# Altium schematic sheet 03_BK323334.SchDoc(03_BK323334)
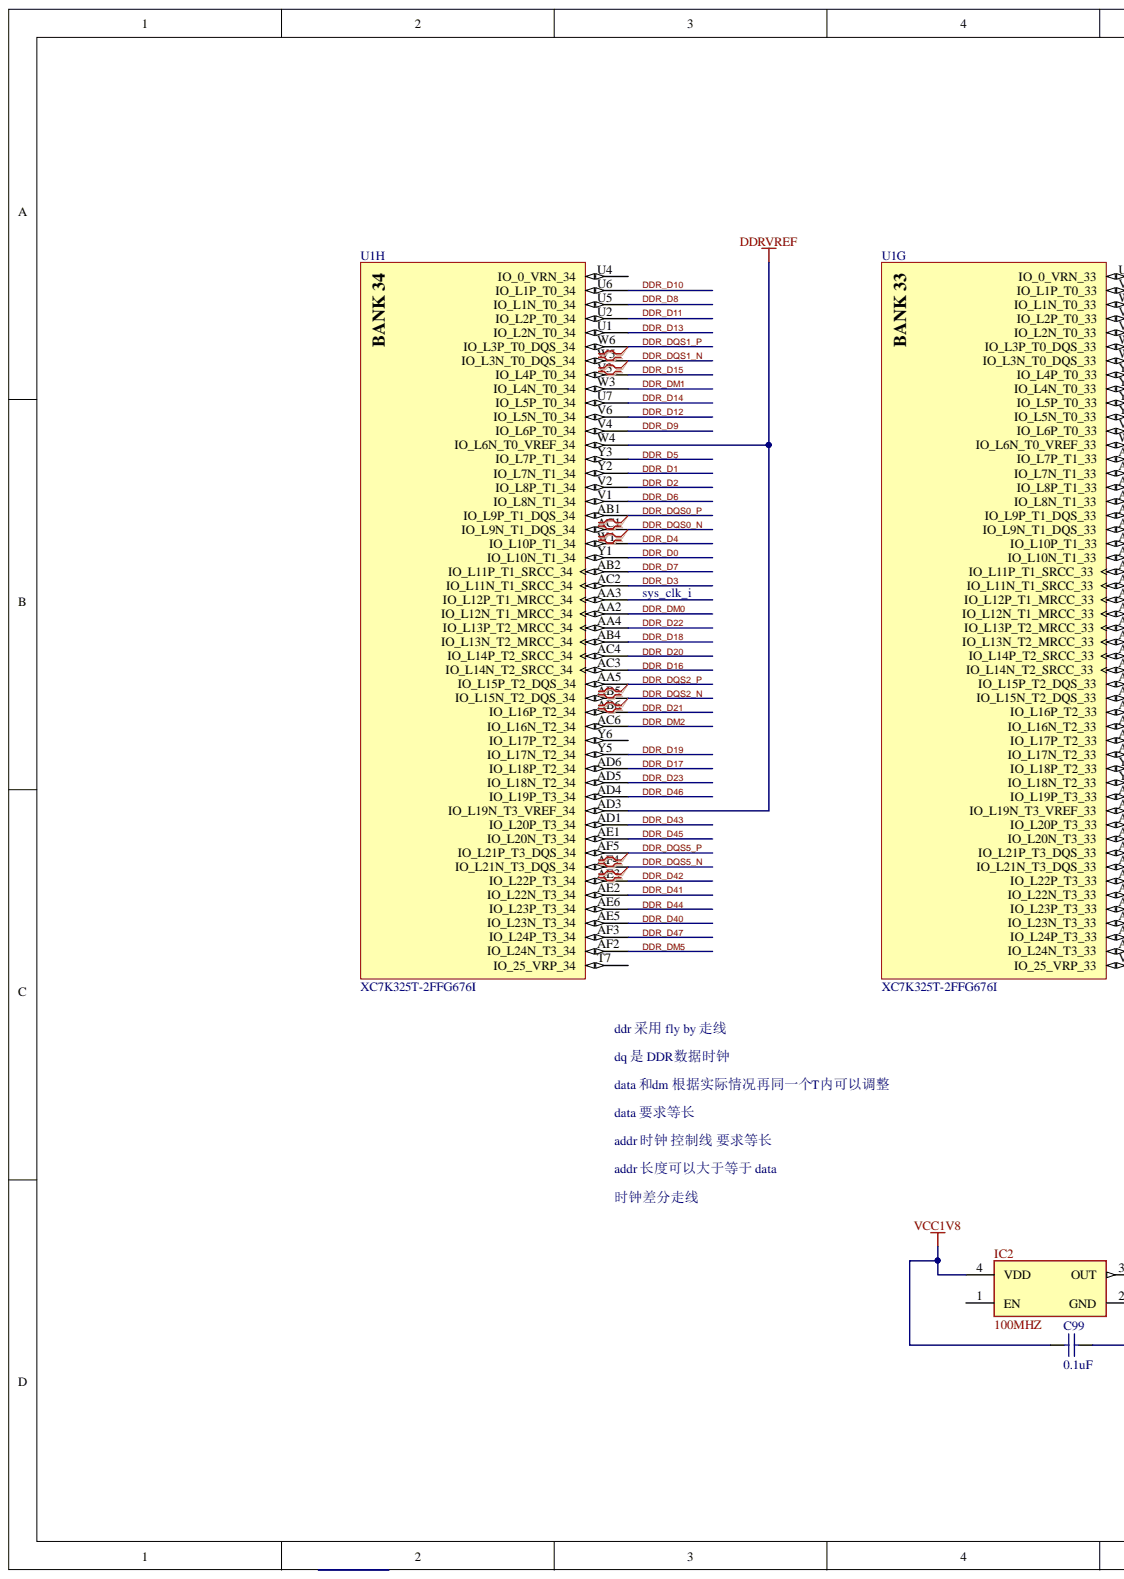
[diagram p0: 03_BK323334.SchDoc, left]
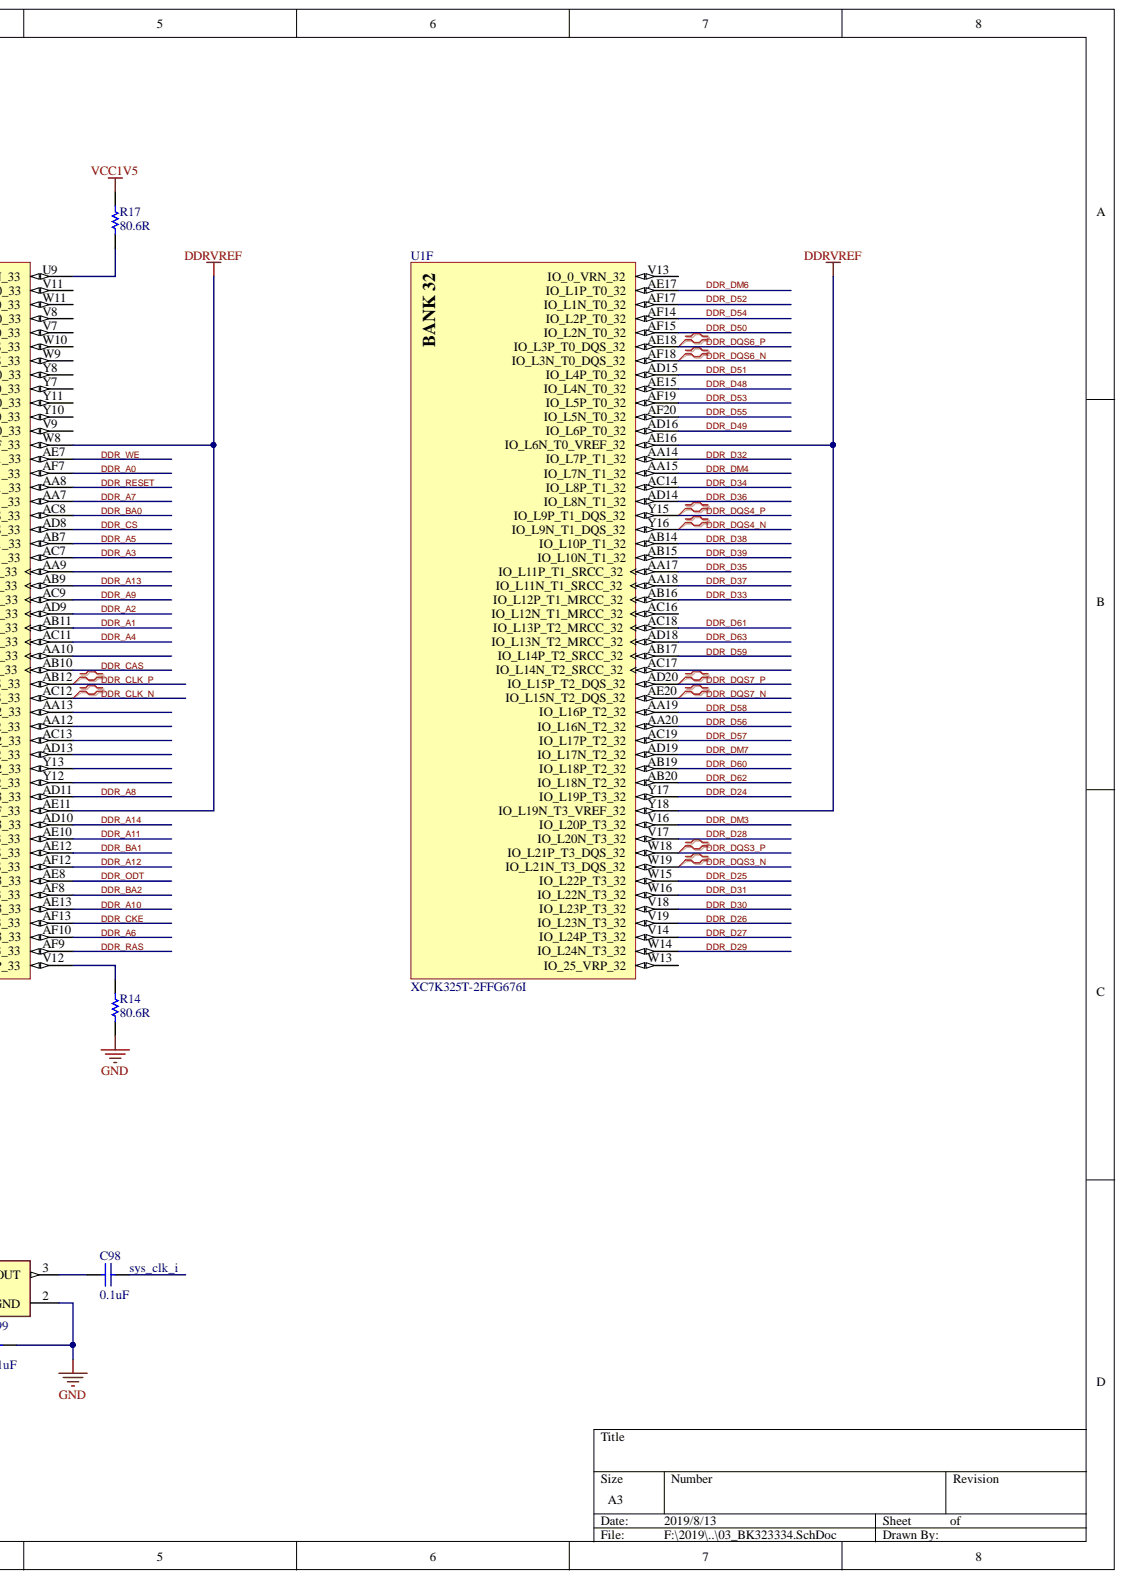
[diagram p1: 03_BK323334.SchDoc, right]

## Parts
C98  0.1uF  [CAP0402]
C99  0.1uF  [CAP0402]
IC2  100MHZ  [3225]
R14  80.6R  [R0402]
R17  80.6R  [R0402]
U1  XC7K325T-2FFG676I  [FFG676]  (parts F,G,H)

## Nets
DDRVREF: U1.AD3(IO_L19N_T3_VREF_34) U1.AE11(IO_L19N_T3_VREF_33) U1.AE16(IO_L6N_T0_VREF_32) U1.W4(IO_L6N_T0_VREF_34) U1.W8(IO_L6N_T0_VREF_33) U1.Y18(IO_L19N_T3_VREF_32)
GND: C99.2 IC2.2(GND) R14.1
VCC1V5: R17.2
VCC1V8: C99.1 IC2.4(VDD)
DDR_A0: U1.AF7(IO_L7N_T1_33)
DDR_A1: U1.AB11(IO_L13P_T2_MRCC_33)
DDR_A2: U1.AD9(IO_L12N_T1_MRCC_33)
DDR_A3: U1.AC7(IO_L10N_T1_33)
DDR_A4: U1.AC11(IO_L13N_T2_MRCC_33)
DDR_A5: U1.AB7(IO_L10P_T1_33)
DDR_A6: U1.AF10(IO_L24P_T3_33)
DDR_A7: U1.AA7(IO_L8N_T1_33)
DDR_A8: U1.AD11(IO_L19P_T3_33)
DDR_A9: U1.AC9(IO_L12P_T1_MRCC_33)
DDR_A10: U1.AE13(IO_L23P_T3_33)
DDR_A11: U1.AE10(IO_L20N_T3_33)
DDR_A12: U1.AF12(IO_L21N_T3_DQS_33)
DDR_A13: U1.AB9(IO_L11N_T1_SRCC_33)
DDR_A14: U1.AD10(IO_L20P_T3_33)
DDR_BA0: U1.AC8(IO_L9P_T1_DQS_33)
DDR_BA1: U1.AE12(IO_L21P_T3_DQS_33)
DDR_BA2: U1.AF8(IO_L22N_T3_33)
DDR_CAS: U1.AB10(IO_L14N_T2_SRCC_33)
DDR_CKE: U1.AF13(IO_L23N_T3_33)
DDR_CLK_N: U1.AC12(IO_L15N_T2_DQS_33)
DDR_CLK_P: U1.AB12(IO_L15P_T2_DQS_33)
DDR_CS: U1.AD8(IO_L9N_T1_DQS_33)
DDR_D0: U1.Y1(IO_L10N_T1_34)
DDR_D1: U1.Y2(IO_L7N_T1_34)
DDR_D2: U1.V2(IO_L8P_T1_34)
DDR_D3: U1.AC2(IO_L11N_T1_SRCC_34)
DDR_D4: U1.W1(IO_L10P_T1_34)
DDR_D5: U1.Y3(IO_L7P_T1_34)
DDR_D6: U1.V1(IO_L8N_T1_34)
DDR_D7: U1.AB2(IO_L11P_T1_SRCC_34)
DDR_D8: U1.U5(IO_L1N_T0_34)
DDR_D9: U1.V4(IO_L6P_T0_34)
DDR_D10: U1.U6(IO_L1P_T0_34)
DDR_D11: U1.U2(IO_L2P_T0_34)
DDR_D12: U1.V6(IO_L5N_T0_34)
DDR_D13: U1.U1(IO_L2N_T0_34)
DDR_D14: U1.U7(IO_L5P_T0_34)
DDR_D15: U1.V3(IO_L4P_T0_34)
DDR_D16: U1.AC3(IO_L14N_T2_SRCC_34)
DDR_D17: U1.AD6(IO_L18P_T2_34)
DDR_D18: U1.AB4(IO_L13N_T2_MRCC_34)
DDR_D19: U1.Y5(IO_L17N_T2_34)
DDR_D20: U1.AC4(IO_L14P_T2_SRCC_34)
DDR_D21: U1.AB6(IO_L16P_T2_34)
DDR_D22: U1.AA4(IO_L13P_T2_MRCC_34)
DDR_D23: U1.AD5(IO_L18N_T2_34)
DDR_D24: U1.Y17(IO_L19P_T3_32)
DDR_D25: U1.W15(IO_L22P_T3_32)
DDR_D26: U1.V19(IO_L23N_T3_32)
DDR_D27: U1.V14(IO_L24P_T3_32)
DDR_D28: U1.V17(IO_L20N_T3_32)
DDR_D29: U1.W14(IO_L24N_T3_32)
DDR_D30: U1.V18(IO_L23P_T3_32)
DDR_D31: U1.W16(IO_L22N_T3_32)
DDR_D32: U1.AA14(IO_L7P_T1_32)
DDR_D33: U1.AB16(IO_L12P_T1_MRCC_32)
DDR_D34: U1.AC14(IO_L8P_T1_32)
DDR_D35: U1.AA17(IO_L11P_T1_SRCC_32)
DDR_D36: U1.AD14(IO_L8N_T1_32)
DDR_D37: U1.AA18(IO_L11N_T1_SRCC_32)
DDR_D38: U1.AB14(IO_L10P_T1_32)
DDR_D39: U1.AB15(IO_L10N_T1_32)
DDR_D40: U1.AE5(IO_L23N_T3_34)
DDR_D41: U1.AE2(IO_L22N_T3_34)
DDR_D42: U1.AE3(IO_L22P_T3_34)
DDR_D43: U1.AD1(IO_L20P_T3_34)
DDR_D44: U1.AE6(IO_L23P_T3_34)
DDR_D45: U1.AE1(IO_L20N_T3_34)
DDR_D46: U1.AD4(IO_L19P_T3_34)
DDR_D47: U1.AF3(IO_L24P_T3_34)
DDR_D48: U1.AE15(IO_L4N_T0_32)
DDR_D49: U1.AD16(IO_L6P_T0_32)
DDR_D50: U1.AF15(IO_L2N_T0_32)
DDR_D51: U1.AD15(IO_L4P_T0_32)
DDR_D52: U1.AF17(IO_L1N_T0_32)
DDR_D53: U1.AF19(IO_L5P_T0_32)
DDR_D54: U1.AF14(IO_L2P_T0_32)
DDR_D55: U1.AF20(IO_L5N_T0_32)
DDR_D56: U1.AA20(IO_L16N_T2_32)
DDR_D57: U1.AC19(IO_L17P_T2_32)
DDR_D58: U1.AA19(IO_L16P_T2_32)
DDR_D59: U1.AB17(IO_L14P_T2_SRCC_32)
DDR_D60: U1.AB19(IO_L18P_T2_32)
DDR_D61: U1.AC18(IO_L13P_T2_MRCC_32)
DDR_D62: U1.AB20(IO_L18N_T2_32)
DDR_D63: U1.AD18(IO_L13N_T2_MRCC_32)
DDR_DM0: U1.AA2(IO_L12N_T1_MRCC_34)
DDR_DM1: U1.W3(IO_L4N_T0_34)
DDR_DM2: U1.AC6(IO_L16N_T2_34)
DDR_DM3: U1.V16(IO_L20P_T3_32)
DDR_DM4: U1.AA15(IO_L7N_T1_32)
DDR_DM5: U1.AF2(IO_L24N_T3_34)
DDR_DM6: U1.AE17(IO_L1P_T0_32)
DDR_DM7: U1.AD19(IO_L17N_T2_32)
DDR_DQS0_N: U1.AC1(IO_L9N_T1_DQS_34)
DDR_DQS0_P: U1.AB1(IO_L9P_T1_DQS_34)
DDR_DQS1_N: U1.W5(IO_L3N_T0_DQS_34)
DDR_DQS1_P: U1.W6(IO_L3P_T0_DQS_34)
DDR_DQS2_N: U1.AB5(IO_L15N_T2_DQS_34)
DDR_DQS2_P: U1.AA5(IO_L15P_T2_DQS_34)
DDR_DQS3_N: U1.W19(IO_L21N_T3_DQS_32)
DDR_DQS3_P: U1.W18(IO_L21P_T3_DQS_32)
DDR_DQS4_N: U1.Y16(IO_L9N_T1_DQS_32)
DDR_DQS4_P: U1.Y15(IO_L9P_T1_DQS_32)
DDR_DQS5_N: U1.AF4(IO_L21N_T3_DQS_34)
DDR_DQS5_P: U1.AF5(IO_L21P_T3_DQS_34)
DDR_DQS6_N: U1.AF18(IO_L3N_T0_DQS_32)
DDR_DQS6_P: U1.AE18(IO_L3P_T0_DQS_32)
DDR_DQS7_N: U1.AE20(IO_L15N_T2_DQS_32)
DDR_DQS7_P: U1.AD20(IO_L15P_T2_DQS_32)
DDR_ODT: U1.AE8(IO_L22P_T3_33)
DDR_RAS: U1.AF9(IO_L24N_T3_33)
DDR_RESET: U1.AA8(IO_L8P_T1_33)
DDR_WE: U1.AE7(IO_L7P_T1_33)
SYS_CLK_I: C98.2 U1.AA3(IO_L12P_T1_MRCC_34)
NetC98_1: C98.1 IC2.3(OUT)
NetR14_2: R14.2 U1.V12(IO_25_VRP_33)
NetR17_1: R17.1 U1.U9(IO_0_VRN_33)
N00370:

Not connected: IC2 1(EN); U1 AA9(IO_L11P_T1_SRCC_33) AA10(IO_L14P_T2_SRCC_33) AA12(IO_L16N_T2_33) AA13(IO_L16P_T2_33) AC13(IO_L17P_T2_33) AC16(IO_L12N_T1_MRCC_32) AC17(IO_L14N_T2_SRCC_32) AD13(IO_L17N_T2_33) T7(IO_25_VRP_34) U4(IO_0_VRN_34) V7(IO_L2N_T0_33) V8(IO_L2P_T0_33) V9(IO_L6P_T0_33) V11(IO_L1P_T0_33) V13(IO_0_VRN_32) W9(IO_L3N_T0_DQS_33) W10(IO_L3P_T0_DQS_33) W11(IO_L1N_T0_33) W13(IO_25_VRP_32) Y6(IO_L17P_T2_34) Y7(IO_L4N_T0_33) Y8(IO_L4P_T0_33) Y10(IO_L5N_T0_33) Y11(IO_L5P_T0_33) Y12(IO_L18N_T2_33) Y13(IO_L18P_T2_33)

## Library data of the parts
C98 C99 = Cap1: Component Kind: Standard; Library Name: M7Z100CORE.IntLib
IC2 = FXO-HC5: 3.3V HCMOS Oscillator; Manufacturer: Fox Electronics; Component Kind: Standard; Library Name: Digilent.IntLib; Value: 100MHz
R14 R17 = Res: Component Kind: Standard; Library Name: MIZ7035_FD.IntLib
U1 = XC7K325T-2FFG676I: Kintex-7 FPGA, 500 User I/Os, 8 GTX, 676-Ball BGA, Speed Grade 2, Industrial Grade, Pb-Free; Manufacturer: Xilinx; GTX: 8; SelectIO HP: 150; SelectIO HR: 250; Speed Grade: -2; Code_JEDEC: MS-034-AAL-1; Component Kind: Standard; ComponentLink1Description: Datasheet; ComponentLink1URL: http://www.xilinx.com/support/documentation/data_sheets/ds180_7Series_Overview.pdf; ComponentLink2Description: Package Specification; ComponentLink2URL: http://www.xilinx.com/support/documentation/user_guides/ug865-Zynq-7000-Pkg-Pinout.pdf; DatasheetVersion: v1.12; Generic Part Number: XC7K325T; Library Name: Xilinx Kintex-7.SchLib; Mounting Technology: Surface Mount; PackageDescription: Flip-Chip BGA, Body 27 x 27 mm, 1.0 mm Pitch; PackageReference: FFG676; PackageVersion: Rev 1.1; PartNumber: XC7K325T-2FFG676I; RoHS: Pb-Free
